# Altium schematic sheet MB_distribution_v2.SchDoc
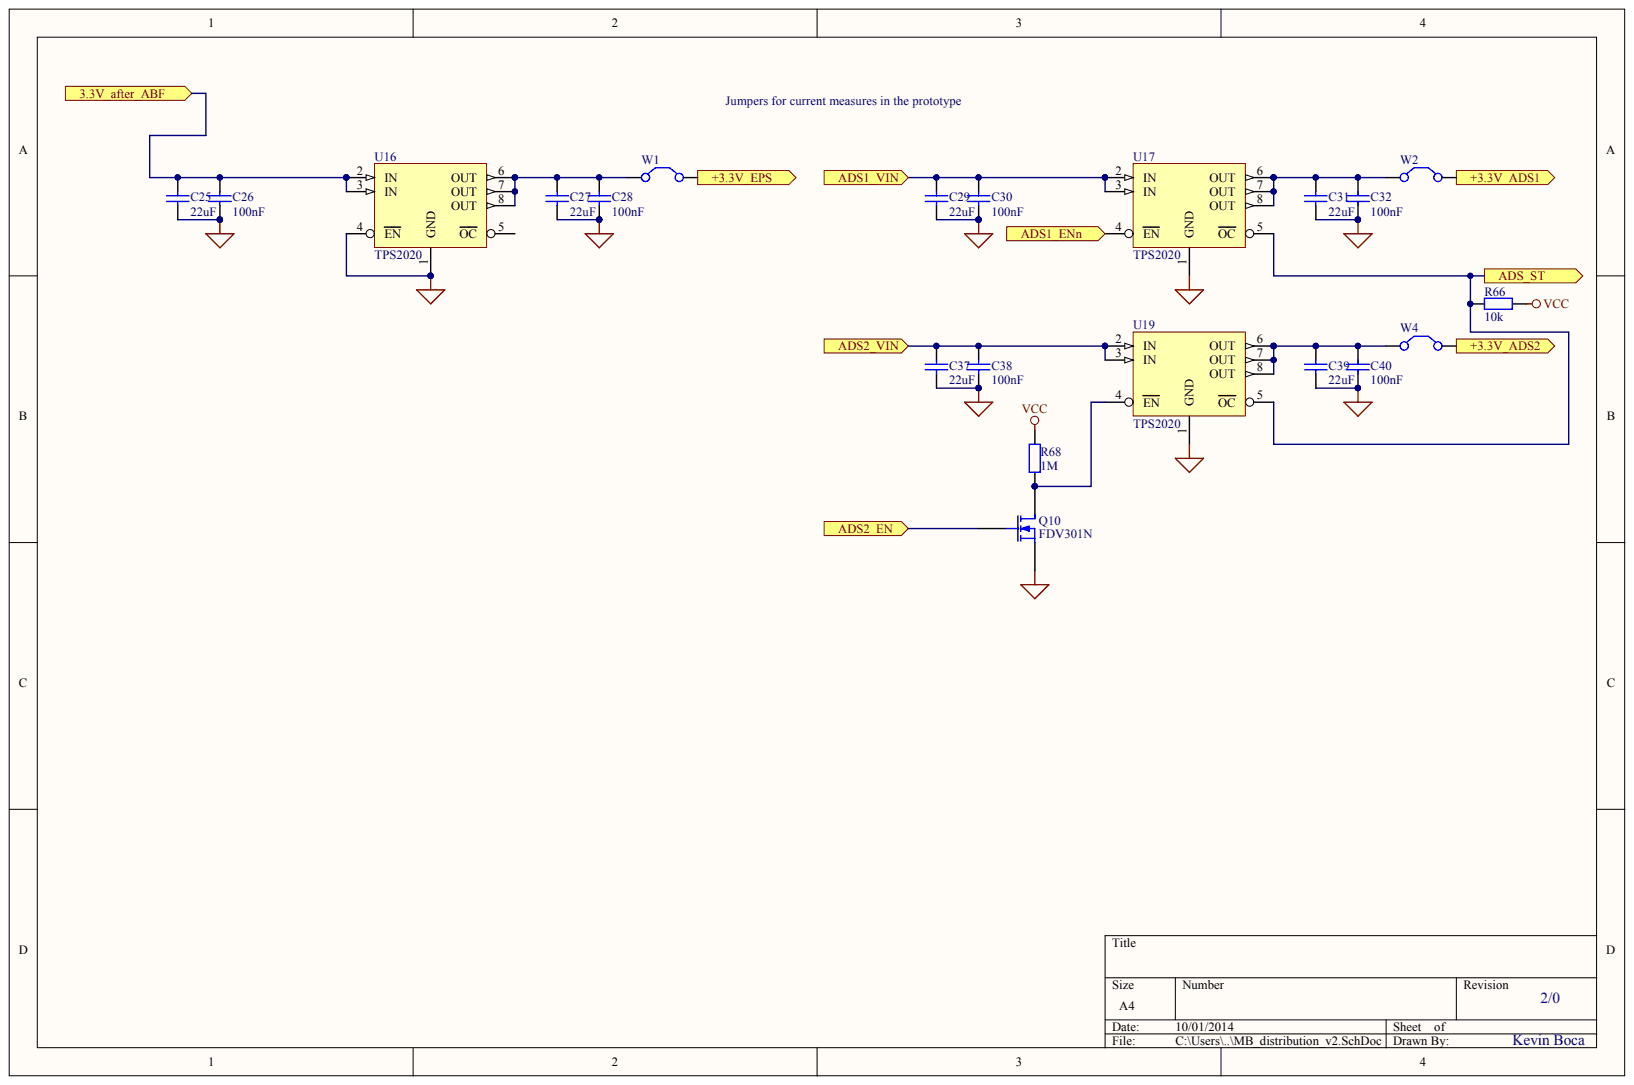
[diagram p0: MB_distribution_v2.SchDoc]

## Parts
C25  22uF
C26  100nF
C27  22uF
C28  100nF
C29  22uF
C30  100nF
C31  22uF
C32  100nF
C37  22uF
C38  100nF
C39  22uF
C40  100nF
Q10  FDV301N
R66  10k
R68  1M
U16  TPS2020
U17  TPS2020
U19  TPS2020
W1  Jumper  (value not drawn)
W2  Jumper  (value not drawn)
W4  Jumper  (value not drawn)

## Ports
3.3V_AFTER_ABF, +3.3V_ADS1, +3.3V_ADS2, +3.3V_EPS, ADS1_ENN, ADS1_VIN, ADS2_EN, ADS2_VIN, ADS_ST

## Nets
GND: C25.1 C26.1 C27.1 C28.1 C29.1 C30.1 C31.1 C32.1 C37.1 C38.1 C39.1 C40.1 Q10.1 U16.1(GND) U16.4(EN) U17.1(GND) U19.1(GND)
VCC: R66.2 R68.1
+3.3V_ADS1: W2.2 ; port +3.3V_ADS1
+3.3V_ADS2: W4.2 ; port +3.3V_ADS2
+3.3V_EPS: W1.2 ; port +3.3V_EPS
ADS1_VIN: C29.2 C30.2 U17.2(IN) U17.3(IN) ; port ADS1_VIN
ADS2_EN: Q10.2 ; port ADS2_EN
ADS2_VIN: C37.2 C38.2 U19.2(IN) U19.3(IN) ; port ADS2_VIN
ADS_ST: R66.1 U17.5(OC) U19.5(OC) ; port ADS_ST
NetC25_2: C25.2 C26.2 U16.2(IN) U16.3(IN) ; port 3.3V_AFTER_ABF
NetC27_2: C27.2 C28.2 U16.6(OUT) U16.7(OUT) U16.8(OUT) W1.1
NetC31_2: C31.2 C32.2 U17.6(OUT) U17.7(OUT) U17.8(OUT) W2.1
NetC39_2: C39.2 C40.2 U19.6(OUT) U19.7(OUT) U19.8(OUT) W4.1
NetQ10_3: Q10.3 R68.2 U19.4(EN)
NetU17_4: U17.4(EN) ; port ADS1_ENN

Not connected: U16 5(OC)

## Library data of the parts
C25-C32 C37-C40 Q10 R66 R68 U16 U17 U19 W1 W2 W4 = (no symbol name)
  C25 C27 C29 C31 C37 C39: Published: 8-Jun-2000; LatestRevisionDate: 17-Jul-2002; LatestRevisionNote: Re-released for DXP Platform.; PackageReference: RAD-0.3; PackageDescription: Radial Cap, Thru-Hole; 2 Leads; 0.3 in Pin Spacing; Value: 22uF
  C26 C28 C30 C32 C38 C40: Published: 8-Jun-2000; LatestRevisionDate: 17-Jul-2002; LatestRevisionNote: Re-released for DXP Platform.; PackageReference: RAD-0.3; PackageDescription: Radial Cap, Thru-Hole; 2 Leads; 0.3 in Pin Spacing; Value: 100nF
  Q10: Published: 8-Jun-2000; LatestRevisionDate: 17-Jul-2002; LatestRevisionNote: Re-released for DXP Platform.; PackageVersion: 1995/1996; PackageReference: E3; PackageDescription: TO, Flat Index; 3 In-Line, Axial Leads; Body Dia. 4.6mm; Lead Dia. 0.5 mm (max)
  R66: Published: 8-Jun-2000; LatestRevisionDate: 17-Jul-2002; LatestRevisionNote: Re-released for DXP Platform.; PackageReference: AXIAL-0.4; PackageDescription: Axial Device, Thru-Hole; 2 Leads; 0.4 in Pin Spacing; Value: 10k
  R68: Published: 8-Jun-2000; LatestRevisionDate: 17-Jul-2002; LatestRevisionNote: Re-released for DXP Platform.; PackageReference: AXIAL-0.4; PackageDescription: Axial Device, Thru-Hole; 2 Leads; 0.4 in Pin Spacing; Value: 1M
  W1 W2 W4: Published: 24-Mar-1999; LatestRevisionDate: 17-Jul-2002; LatestRevisionNote: Re-released for DXP Platform.; PackageReference: RAD-0.2; PackageDescription: Radial Cap, Thru-Hole; 2 Leads; 0.2 in Pin Spacing
  C25-C32 C37-C40 Q10 R66 R68 W1 W2 W4: Publisher: Altium Limited
